# Altium schematic sheet USBC.SchDoc(USBC)
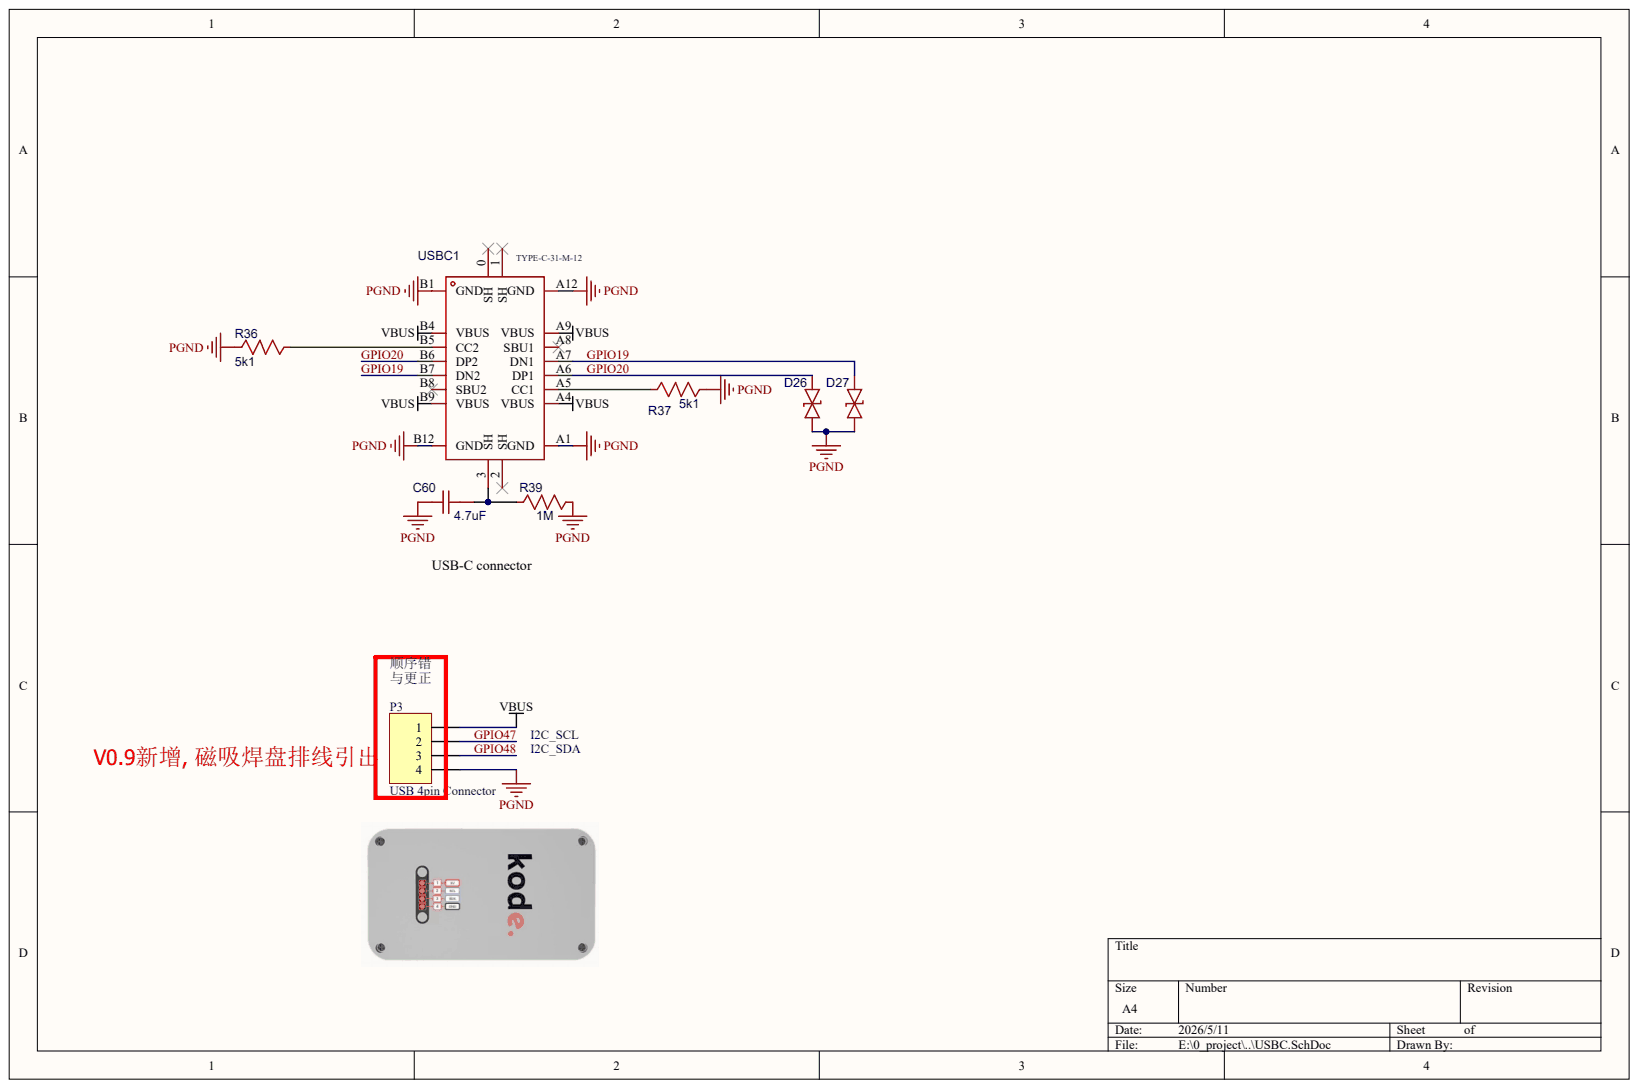
[diagram p0: USBC.SchDoc]

## Parts
C60  4.7uF
D26  LESD5D5.0CT1G  (value not drawn)
D27  LESD5D5.0CT1G  (value not drawn)
P3  USB 4pin Connector
R36  5k1
R37  5k1
R39  1M
USBC1  USB  Symbol: TYPE-C-31-M-12

## Nets
PGND: C60.1 D26.1 D27.1 P3.4 R36.2 R37.1 R39.1 USBC1.A1(GND) USBC1.A12(GND) USBC1.B1(GND) USBC1.B12(GND)
VBUS: P3.1 USBC1.A4(VBUS) USBC1.A9(VBUS) USBC1.B4(VBUS) USBC1.B9(VBUS)
GPIO19: D27.2 USBC1.A7(DN1) USBC1.B7(DN2)
GPIO20: D26.2 USBC1.A6(DP1) USBC1.B6(DP2)
GPIO47: P3.2
GPIO48: P3.3
NetC60_2: C60.2 R39.2 USBC1.3(SH)
NetR36_1: R36.1 USBC1.B5(CC2)
NetR37_2: R37.2 USBC1.A5(CC1)

Not connected: USBC1 0(SH) 1(SH) 2(SH) A8(SBU1) B8(SBU2)

## Library data of the parts
C60 = (no symbol name): Manufacturer: SAMSUNG; Symbol: 4.7u; Device: CL05A475MP5NRNC; Add into BOM: yes; Origin Footprint: C0402; Supplier Part: C23733; Manufacturer Part: CL05A475MP5NRNC; Supplier Footprint: 0402; JLCPCB Part Class: Basic Part; Datasheet: https://atta.szlcsc.com/upload/public/pdf/source/20160103/1457707710457.pdf; Supplier: LCSC; Convert to PCB: yes; Value: 4.7uF
D26 D27 = (no symbol name): AddInBom: yes; ModelId: 1c55af31f47d4d9db45f00e5a148cdf3; ModelName: SOD-523_L1.2-W0.8-LS1.6-BI; ModelTransform: 62.992,31.496,0,0,0,0,0,0,0; Symbol: LESD5D5.0CT1G; Device: LESD5D5.0CT1G; Supplier: LCSC; Supplier Part: C383211; Manufacturer Part: LESD5D5.0CT1G; Supplier Footprint: SOD-523; Datasheet: https://atta.szlcsc.com/upload/public/pdf/source/20190807/C383211_633DF4B4E2C161403226E5235FE33A1C.pdf; ConvertToPcb: yes; FootprintName: SOD-523_L1.2-W0.8-LS1.6-BI
R36 R37 = (no symbol name): Manufacturer: WTC; Device: WR04X5111FTL; Add into BOM: yes; Origin Footprint: R0402; Symbol: 5k1; Supplier Part: C170331; Manufacturer Part: WR04X5111FTL; Supplier Footprint: 0402; JLCPCB Part Class: Extended Part; Datasheet: https://atta.szlcsc.com/upload/public/pdf/source/20191217/C470037_D99261402F77DA8B1D609223749D445A.pdf; Supplier: LCSC; Convert to PCB: yes; Type: Thick Film Resistors
R39 = (no symbol name): Manufacturer: UniOhm; Device: 0402WGF1004TCE; Add into BOM: yes; Origin Footprint: R0402; Symbol: 1M; Supplier Part: C26083; Manufacturer Part: 0402WGF1004TCE; Supplier Footprint: 0402; JLCPCB Part Class: Basic Part; Datasheet: https://atta.szlcsc.com/upload/public/pdf/source/20200306/C422600_1E6D84923E4A46A82E41ADD87F860B5C.pdf; Supplier: LCSC; Convert to PCB: yes; Type: Thick Film Resistors
USBC1 = (no symbol name): Add into BOM: yes; Connector Type: Type-C; Gender: Female; Number of Contacts: 16P; Number of Ports: 1; Current Rating - Power (Max): 5A; Origin Footprint: USB-C_SMD-TYPE-C-31-M-12; Device: TYPE-C-31-M-12; Supplier Part: C165948; Manufacturer Part: TYPE-C-31-M-12; Supplier Footprint: SMD; JLCPCB Part Class: Extended Part; Datasheet: https://atta.szlcsc.com/upload/public/pdf/source/20220525/466408E853115E789EDB0DDC29077C05.pdf; Supplier: LCSC; Convert to PCB: yes; Standard: -; Mounting Style: -; Contact Material: -; Contact Plating: -; Operating Temperature Range: -; Welding Temperature (Max): -
P3 = (no symbol name): LatestRevisionDate: 17-Jul-2002; LatestRevisionNote: Re-released for DXP Platform.; Publisher: Altium Limited
